# Altium schematic sheet IMP_PAM8407_Module.SchDoc
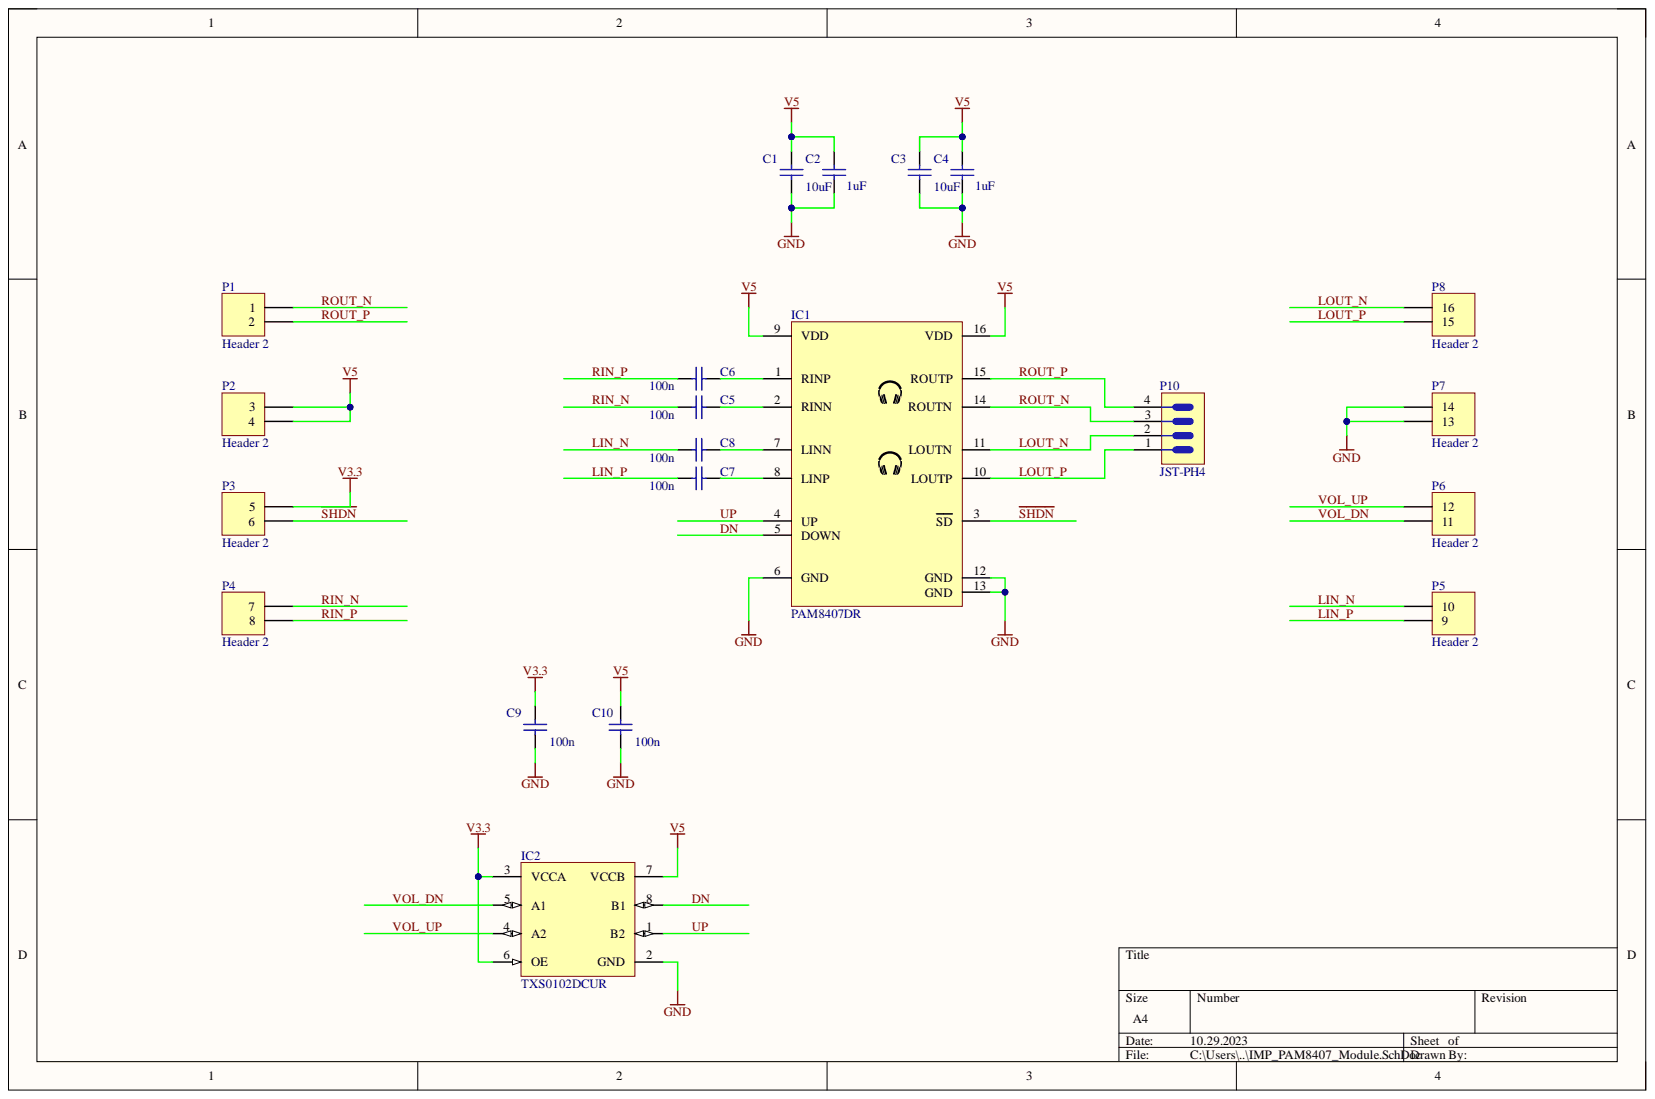
[diagram p0: IMP_PAM8407_Module.SchDoc]

## Parts
C1  10uF  [C0805]
C2  1uF  [C0805]
C3  10uF  [C0805]
C4  1uF  [C0805]
C5  100n  [C0805]
C6  100n  [C0805]
C7  100n  [C0805]
C8  100n  [C0805]
C9  100n  [C0805]
C10  100n  [C0805]
IC1  PAM8407DR  [SOIC-16]
IC2  TXS0102DCUR  [VSSOP-8]
P1  Header 2  [HDR1X2]
P2  Header 2  [HDR1X2]
P3  Header 2  [HDR1X2]
P4  Header 2  [HDR1X2]
P5  Header 2  [HDR1X2]
P6  Header 2  [HDR1X2]
P7  Header 2  [HDR1X2]
P8  Header 2  [HDR1X2]
P10  JST-PH4  [JST-PH4-SMD-R]

## Nets
GND: C1.1 C2.2 C3.1 C4.2 C9.1 C10.1 IC1.6(GND) IC1.12(GND) IC1.13(GND) IC2.2(GND) P7.13 P7.14
V3.3: C9.2 IC2.3(VCCA) IC2.6(OE) P3.5
V5: C1.2 C2.1 C3.2 C4.1 C10.2 IC1.9(VDD) IC1.16(VDD) IC2.7(VCCB) P2.3 P2.4
DN: IC1.5(DOWN) IC2.8(B1)
LIN_N: C8.1 P5.10
LIN_P: C7.1 P5.9
LOUT_N: IC1.11(LOUTN) P8.16 P10.2
LOUT_P: IC1.10(LOUTP) P8.15 P10.1
RIN_N: C5.1 P4.7
RIN_P: C6.1 P4.8
ROUT_N: IC1.14(ROUTN) P1.1 P10.3
ROUT_P: IC1.15(ROUTP) P1.2 P10.4
~{SHDN}: IC1.3(SD) P3.6
UP: IC1.4(UP) IC2.1(B2)
VOL_DN: IC2.5(A1) P6.11
VOL_UP: IC2.4(A2) P6.12
NetC5_2: C5.2 IC1.2(RINN)
NetC6_2: C6.2 IC1.1(RINP)
NetC7_2: C7.2 IC1.8(LINP)
NetC8_2: C8.2 IC1.7(LINN)

## Library data of the parts
C1-C10 = Cap: Capacitor; Value: 100nF; Component Kind: Standard; LatestRevisionDate: 17-Jul-2002; LatestRevisionNote: Re-released for DXP Platform.; Library Name: Miscellaneous Devices.SchLib; PackageReference: 0402; Published: 8-Jun-2000; Signal Integrity: Cap; Simulation: CAP; Publisher: Altium Limited
IC1 = PAM8407DR: 2-CH ,2.4W, Stereo Audio Amp, Class-D, 16-Pin SOIC; Manufacturer_Name: Diodes Inc.; Geometry.Height: 1.75mm; Arrow Part Number: PAM8407DR; Arrow Price/Stock: https://www.arrow.com/en/products/pam8407dr/diodes-incorporated?region=nac; Component Kind: Standard; Datasheet Link: https://datasheet.datasheetarchive.com/originals/distributors/Datasheets-DGA6/531751.pdf; Manufacturer_Part_Number: PAM8407DR; Mouser Part Number: 621-PAM8407DR; Mouser Price/Stock: https://www.mouser.co.uk/ProductDetail/Diodes-Incorporated/PAM8407DR?qs=%252BCmxX1pwNuH%2FvFVR9hBPMw%3D%3D; Library Name: holky.SchLib
IC2 = TXS0102DCUR: 2-Bit Bidirectional Level-Shifting and Voltage Translator  With Auto Direction-Sensing and ±15-kV ESD Protection; Manufacturer: Texas Instruments; Data Rate: 24Mbits/s; Logic Function Desc: Bidirectional Voltage-Level Translator; Max Junction Temp: +150°C; Max Supply Voltage: 5.5V; Min Supply Voltage: 1.65V; Nominal Supply Current: 1-14.4uA; Number Of Circuits: 1; Number Of Inputs: 2; Standoff Height: 0mm; V A Max: 3.6V; V B Min: 2.3V; Ambient Temperature Range High: +85°C; Ambient Temperature Range Low: -40°C; Automotive: No; Category: IC; Component Kind: Standard; ComponentLink2Description: Datasheet; ComponentLink2URL: http://www.ti.com/lit/ds/symlink/txs0102.pdf; Device Class L1: Integrated Circuits (ICs); Device Class L2: Logic ICs; Device Class L3: Voltage Level Shifters; Digikey Description: IC TRNSLTR BIDIRECTIONAL US8; Height: 0.9mm; Lead Free: Yes; Manufacturer Part Number: TXS0102DCUR; Mouser Description: Translation - Voltage Levels 2-Bit Bi-Directional Voltage-Level-Trans; Number Of Outputs: 2; Octopart: https://octopart.com/txs0102dcur-texas+instruments-5414308; Package: DCU0008A; RoHS: TRUE; Temperature: -40°C to +85°C; Temperature Range High: +85°C; Temperature Range Low: -40°C; V A Min: 1.65V; V B Max: 5.5V; Library Name: holky.SchLib
P1-P8 = Header 2: Header, 2-Pin; Component Kind: Standard; LatestRevisionDate: 17-Jul-2002; LatestRevisionNote: Re-released for DXP Platform.; Library Name: Miscellaneous Connectors.SchLib; Signal Integrity: Connector; Publisher: Altium Limited
P10 = JST-PH4: Connector Header Surface Mount, Right Angle 4 position 0.079 _2.00mm_; MF: JST Sales; MP: S4B-PH-SM4-TB; Availability: Unavailable; Component Kind: Standard; Package: None; Price: None; SnapEDA_Link: https://www.snapeda.com/parts/S4B-PH-SM4-TB/JST%20Sales%20America%20Inc./view-part/473686/?ref=snap; Library Name: holky.SchLib
